# Altium schematic sheet Sheet1.SchDoc
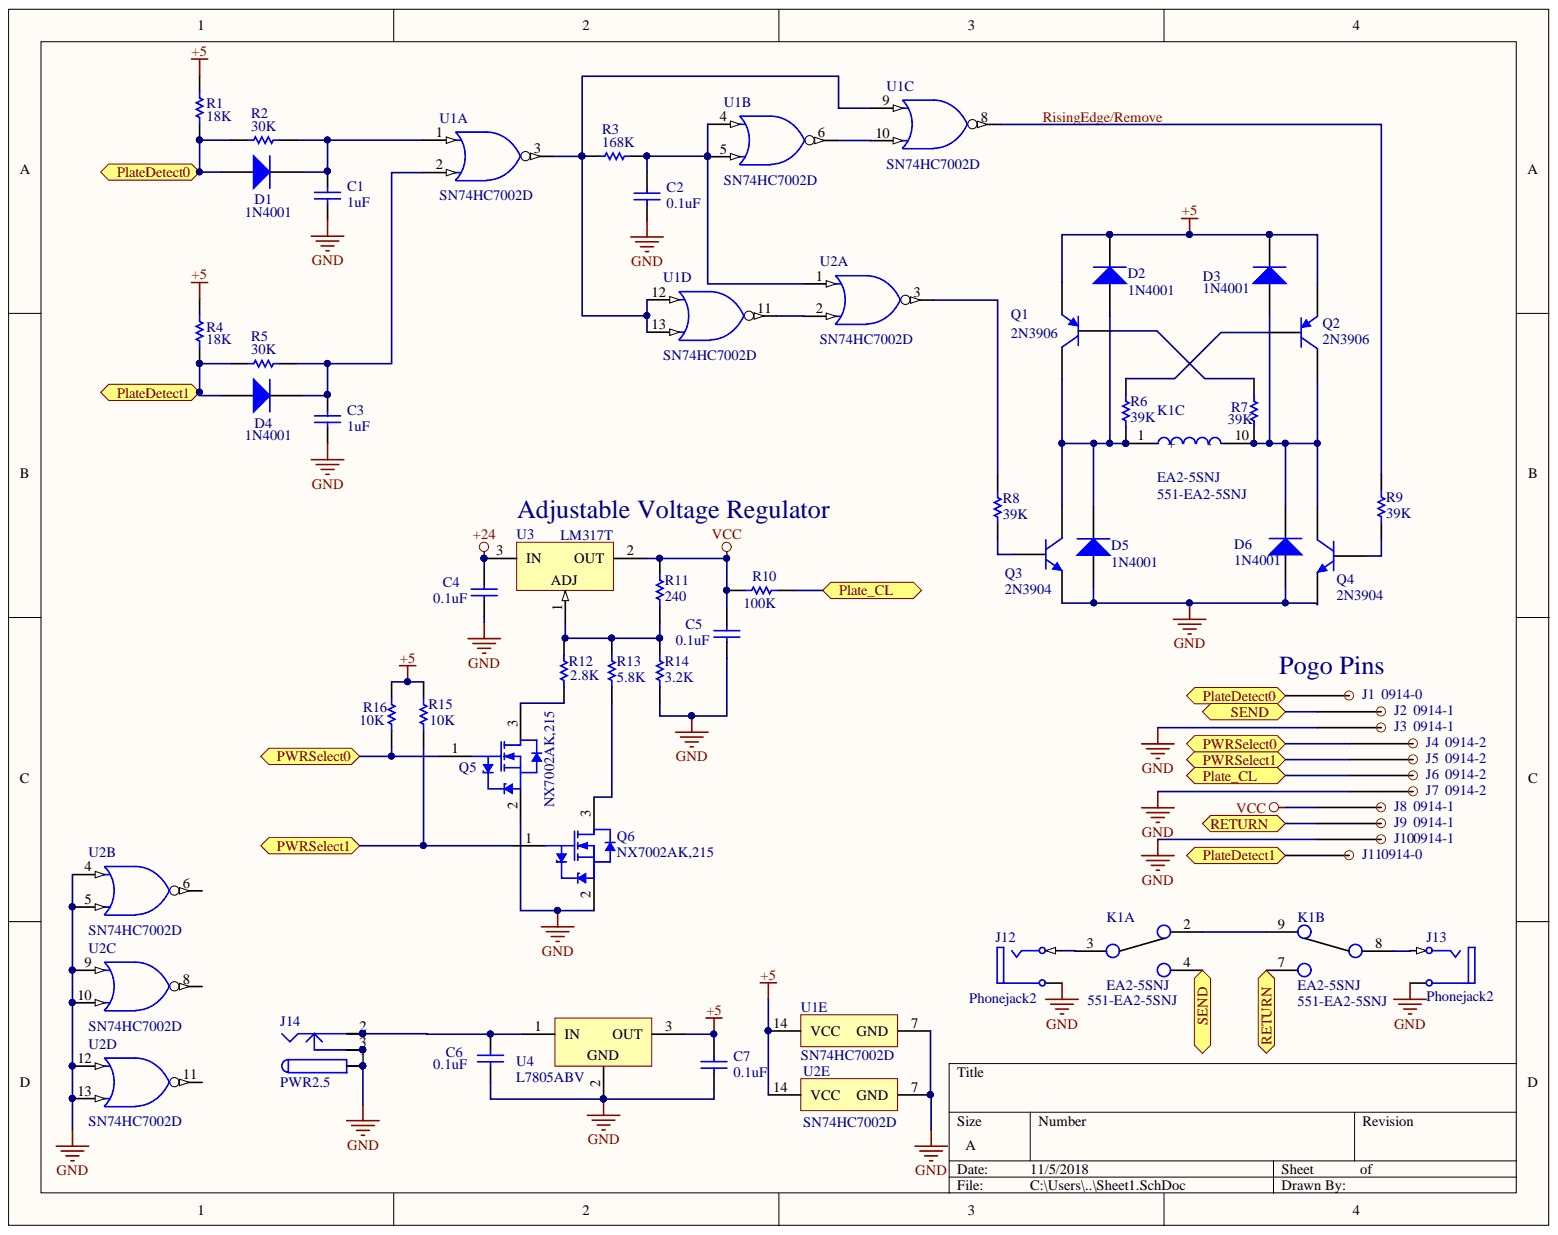
[diagram p0: Sheet1.SchDoc]

## Parts
C1  value 1uF  [RAD-0.3]  (hidden comment: Cap)
C2  value 0.1uF  [RAD-0.3]  (hidden comment: Cap)
C3  value 1uF  [RAD-0.3]  (hidden comment: Cap)
C4  value 0.1uF  [RAD-0.3]  (hidden comment: Cap)
C5  value 0.1uF  [RAD-0.3]  (hidden comment: Cap)
C6  value 0.1uF  [RAD-0.3]  (hidden comment: Cap)
C7  value 0.1uF  [RAD-0.3]  (hidden comment: Cap)
D1  1N4001  [SMC]
D2  1N4001  [SMC]
D3  1N4001  [SMC]
D4  1N4001  [SMC]
D5  1N4001  [SMC]
D6  1N4001  [SMC]
J1  0914-0-15-20-77-14-11-0  [MillMax0_025]  (value not drawn)
J2  0914-1-15-20-77-14-11-0  [MillMax0_025]  (value not drawn)
J3  0914-1-15-20-77-14-11-0  [MillMax0_025]  (value not drawn)
J4  0914-2-15-20-77-14-11-0  [MillMax0_025]  (value not drawn)
J5  0914-2-15-20-77-14-11-0  [MillMax0_025]  (value not drawn)
J6  0914-2-15-20-77-14-11-0  [MillMax0_025]  (value not drawn)
J7  0914-2-15-20-77-14-11-0  [MillMax0_025]  (value not drawn)
J8  0914-1-15-20-77-14-11-0  [MillMax0_025]  (value not drawn)
J9  0914-1-15-20-77-14-11-0  [MillMax0_025]  (value not drawn)
J10  0914-1-15-20-77-14-11-0  [MillMax0_025]  (value not drawn)
J11  0914-0-15-20-77-14-11-0  [MillMax0_025]  (value not drawn)
J12  Phonejack2  [JACK/6-V2]
J13  Phonejack2  [JACK/6-V2]
J14  PWR2.5  [KLD-0202]
K1  EA2-5SNJ  Supplier Part Number 1: 551-EA2-5SNJ  (parts A,B,C)
Q1  2N3906  [TO-92A]
Q2  2N3906  [TO-92A]
Q3  2N3904  [TO-92A]
Q4  2N3904  [TO-92A]
Q5  NX7002AK,215  [NXP-SOT23_N]
Q6  NX7002AK,215  [NXP-SOT23_N]
R1  value 18K  [AXIAL-0.3]  (hidden comment: Res1)
R2  value 30K  [AXIAL-0.3]  (hidden comment: Res1)
R3  value 168K  [AXIAL-0.3]  (hidden comment: Res1)
R4  value 18K  [AXIAL-0.3]  (hidden comment: Res1)
R5  value 30K  [AXIAL-0.3]  (hidden comment: Res1)
R6  value 39K  [AXIAL-0.3]  (hidden comment: Res1)
R7  value 39K  [AXIAL-0.3]  (hidden comment: Res1)
R8  value 39K  [AXIAL-0.3]  (hidden comment: Res1)
R9  value 39K  [AXIAL-0.3]  (hidden comment: Res1)
R10  value 100K  [AXIAL-0.3]  (hidden comment: Res1)
R11  value 240  [AXIAL-0.3]  (hidden comment: Res1)
R12  value 2.8K  [AXIAL-0.3]  (hidden comment: Res1)
R13  value 5.8K  [AXIAL-0.3]  (hidden comment: Res1)
R14  value 3.2K  [AXIAL-0.3]  (hidden comment: Res1)
R15  value 10K  [AXIAL-0.3]  (hidden comment: Res1)
R16  value 10K  [AXIAL-0.3]  (hidden comment: Res1)
U1  SN74HC7002D  [D0014A_N]  (parts A,B,C,D,E)
U2  SN74HC7002D  [D0014A_N]  (parts A,B,C,D,E)
U3  LM317T  [TO-220]
U4  L7805ABV  [TO220]

## Ports
Plate_CL, PlateDetect0, PlateDetect1, PWRSelect0, PWRSelect1, RETURN, SEND

## Nets
+5: C7.2 D2.2 D3.2 Q1.1 Q2.1 R1.2 R4.2 R15.2 R16.2 U1.14(VCC) U2.14(VCC) U4.3
+24: C4.2 U3.3
GND: C1.1 C2.1 C3.1 C4.1 C5.1 C6.1 C7.1 D5.1 D6.1 J3.1 J7.1 J10.1 J12.5 J13.5 J14.1 J14.1A J14.1B Q3.1 Q4.1 Q5.2 Q6.2 R14.1 U1.7(GND) U2.4 U2.5 U2.7(GND) U2.9 U2.10 U2.12 U2.13 U4.2
VCC: C5.2 J8.1 R10.2 R11.2 U3.2
Plate_CL: J6.1 R10.1 ; port Plate_CL
PlateDetect0: D1.1 J1.1 R1.1 R2.1 ; port PlateDetect0
PlateDetect1: D4.1 J11.1 R4.1 R5.1 ; port PlateDetect1
PWRSelect0: J4.1 Q5.1 R16.1 ; port PWRSelect0
PWRSelect1: J5.1 Q6.1 R15.1 ; port PWRSelect1
RETURN: J9.1 K1.7 ; port RETURN
RisingEdge/Remove: R9.1 U1.8
SEND: J2.1 K1.4 ; port SEND
NetC1_2: C1.2 D1.2 R2.2 U1.1
NetC2_2: C2.2 R3.2 U1.4 U1.5 U2.1
NetC3_2: C3.2 D4.2 R5.2 U1.2
NetC6_2: C6.2 J14.2 J14.2A J14.2B U4.1
NetD2_1: D2.1 D5.2 K1.1(+) Q1.3 Q3.3 R6.1
NetD3_1: D3.1 D6.2 K1.10(-) Q2.3 Q4.3 R7.1
NetJ12_1: J12.1 K1.3
NetJ13_1: J13.1 K1.8
NetJ14_3: J14.3 J14.3A J14.3B
NetK1_2: K1.2 K1.9
NetQ1_2: Q1.2 R7.2
NetQ2_2: Q2.2 R6.2
NetQ3_2: Q3.2 R8.2
NetQ4_2: Q4.2 R9.2
NetQ5_3: Q5.3 R12.1
NetQ6_3: Q6.3 R13.1
NetR3_1: R3.1 U1.3 U1.9 U1.12 U1.13
NetR8_1: R8.1 U2.3
NetR11_1: R11.1 R12.2 R13.2 R14.2 U3.1
NetU1_6: U1.6 U1.10
NetU1_11: U1.11 U2.2

Not connected: U2 6 8 11

## Library data of the parts
C1-C7 = Cap: Capacitor; Component Kind: Standard; LatestRevisionDate: 17-Jul-2002; LatestRevisionNote: Re-released for DXP Platform.; Library Name: Miscellaneous Devices.IntLib; PackageDescription: Radial Cap, Thru-Hole; 2 Leads; 0.3 in Pin Spacing; PackageReference: RAD-0.3; Published: 8-Jun-2000; Signal Integrity: Cap; Simulation: CAP; Publisher: Altium Limited
D1-D6 = Diode: Default Diode; Code_JEDEC: DO-214-AB; Component Kind: Standard; LatestRevisionDate: 17-Jul-2002; LatestRevisionNote: Re-released for DXP Platform.; Library Name: Miscellaneous Devices.IntLib; PackageDescription: DO-214-AB/SMC; 2 C-Bend Leads; Body 7.9 x 5.9 mm, inc. leads (LxW); PackageReference: SMC; PackageVersion: Sep-1996; Published: 8-Jun-2000; Signal Integrity: Diode; Simulation: DIODE; Publisher: Altium Limited
J1 J11 = 0914-0-15-20-77-14-11-0: CONN PIN SPRING-LOADED PCB GOLD; Manufacturer: Mill-Max; Body Material: Brass; Current Rating: 2A; Number of Contacts: 2; P*: 0914-0; Resistance: 20mR; Component Kind: Standard; ComponentLink1Description: http://www.mouser.com/ds/2/273/025-259718.pdf; ComponentLink1URL: http://www.mouser.com/ds/2/273/025-259718.pdf; ComponentLink2Description: http://www.farnell.com/datasheets/1474874.pdf; ComponentLink2URL: http://www.farnell.com/datasheets/1474874.pdf; ComponentLink3Description: https://www.alliedelec.com/m/d/29d0a7827c1825043739d39c5225e64e.pdf; ComponentLink3URL: https://www.alliedelec.com/m/d/29d0a7827c1825043739d39c5225e64e.pdf; Contact Material: Copper Alloy; Contact Plating: Gold; Lead Free: Lead Free; Length: 0.302inch; Manufacturer Part Number: 0914-0-15-20-77-14-11-0; Material: Copper Alloy; Mount: Panel,Through Hole; Number of Rows: 1; Package Quantity: 500; Packaging: Bulk; Plating: Gold; Pricing 1: 1=0.75, 10=0.706, 25=0.6352, 50=0.5648, 100=0.5412, 250=0.49412, 500=0.4706, 1000=0.38825, 2500=0.35295 (USD); REACH SVHC: Unknown; Stock 1: 29402; Supplier 1: Digi-Key; Termination: Solder; Library Name: NickPhamParts.IntLib
J2 J3 J8-J10 = 0914-1-15-20-77-14-11-0: CONNECTOR; Manufacturer: Mill-Max; J*: 0914-1; Plating: Gold; Component Kind: Standard; Manufacturer Part Number: 0914-1-15-20-77-14-11-0; Material: Brass; Mount: Through Hole; Pricing 1: 1=0.77, 10=0.673, 25=0.6336, 50=0.6072, 100=0.5808, 250=0.528, 500=0.4752, 1000=0.4224, 2500=0.3828 (USD); Stock 1: 17746; Supplier 1: Digi-Key; Library Name: NickPhamParts.IntLib
J4-J7 = 0914-2-15-20-77-14-11-0: CONN PIN PCB .362"; Manufacturer: Mill-Max; Plating: Gold; Component Kind: Standard; Manufacturer Part Number: 0914-2-15-20-77-14-11-0; Material: Brass; Mount: Through Hole; Pricing 1: 1=0.81, 10=0.71, 25=0.6688, 50=0.6408, 100=0.6129, 250=0.5572, 500=0.50148, 1000=0.44576, 2500=0.40397 (USD); Stock 1: 7703; Supplier 1: Digi-Key; Supplier Part Number 1: 0914-2; Library Name: NickPhamParts.IntLib
J12 J13 = Phonejack2: Jack Socket, 1/4" [6.5mm], Thru-Hole, Vertical, 2-Conductor Open Circuit; DrillAndSlotSize: Corresponds to nominal value.; PackageDocument: 2003; PCB_Layout: Complies with manufacturer's recommendation.; Component Kind: Standard; ComponentLink1Description: Manufacturer Link; ComponentLink1URL: http://www.cliffuk.co.uk/; DatasheetDocument: 2003; LatestRevisionDate: 15-Jul-2004; LatestRevisionNote: Component Replaced.; Library Name: Miscellaneous Connectors.IntLib; PackageReference: S1VS_SNS; Signal Integrity: JACK Socket; Publisher: Altium Limited
J14 = PWR2.5: Low Voltage Power Supply Connector; Component Kind: Standard; ComponentLink1Description: Manufacturer Link; ComponentLink1URL: http://domino.kycon.com/internet/Products.html; DatasheetDocument: 09-May-2002; Library Name: Miscellaneous Connectors.IntLib; Published: 17-Dec-2003; Signal Integrity: Connector; Publisher: Altium Limited
K1 = EA2-5SNJ: Low Signal Relays - PCB 5VDC SINGLE LATCH; Manufacturer: NEC; Coil Current: 2A; Coil Type: Latching; Power Consumption: 100mW; Throw Configuration: DPDT; Component Kind: Standard; Manufacturer Part Number: EA2-5SNJ; Package Quantity: 40; Stock 1: 0; Supplier 1: Mouser; Termination: Solder; Library Name: NickPhamParts.IntLib
Q1 Q2 = 2N3906: PNP General Purpose Amplifier; Component Kind: Standard; ComponentLink1Description: Manufacturer Link; ComponentLink1URL: http://www.fairchildsemi.com/; ComponentLink2Description: Datasheet; ComponentLink2URL: http://www.fairchildsemi.com/ds/2N%2F2N3906.pdf; DatasheetVersion: 1997; LatestRevisionDate: 15-Jan-2003; LatestRevisionNote: PCB Footprint 'BCY-W3/D4.7' replaced; Library Name: Miscellaneous Devices.IntLib; PackageDescription: TO, Flat Index; 3 In-Line, Axial Leads; Body Dia. 4.5mm; Leads 0.51 x 0.48 mm (max); PackageReference: TO-92A; PackageVersion: Sep-1998; Published: 8-Jun-2000; Signal Integrity: 2N3906; Simulation: 2N3906; Publisher: Altium Limited
Q3 Q4 = 2N3904: NPN General Purpose Amplifier; Component Kind: Standard; ComponentLink1Description: Manufacturer Link; ComponentLink1URL: http://www.fairchildsemi.com/; ComponentLink2Description: Datasheet; ComponentLink2URL: http://www.fairchildsemi.com/ds/2N%2F2N3904.pdf; DatasheetVersion: 1997; LatestRevisionDate: 15-Jan-2003; LatestRevisionNote: PCB Footprint 'BCY-W3/D4.7' replaced; Library Name: Miscellaneous Devices.IntLib; PackageDescription: TO, Flat Index; 3 In-Line, Axial Leads; Body Dia. 4.5mm; Leads 0.51 x 0.48 mm (max); PackageReference: TO-92A; PackageVersion: Sep-1998; Published: 8-Jun-2000; Signal Integrity: 2N3904; Simulation: 2N3904; Publisher: Altium Limited
Q5 Q6 = NX7002AK,215: Single N-Channel Trench MOSFET, 60 V, -55 to 150 degC, 3-Pin SOT23, Tape and Reel; Manufacturer: NXP Semiconductors; Channel Type: N; Ciss [typ] (pF): 11; Coss [typ] (pF): 3.4; Packing: Tape & Reel; VDS [max] (V): 60; VGSth [typ] (V): 1.6; Code_JEDEC: TO-236AB; Component Kind: Standard; ComponentLink1Description: Manufacturer URL; ComponentLink1URL: http://www.nxp.com/; ComponentLink2Description: Datasheet; ComponentLink2URL: http://www.nxp.com/documents/data_sheet/NX7002AK.pdf; ComponentLink3Description: Package Specification; ComponentLink3URL: http://www.nxp.com/documents/outline_drawing/sot023_po.pdf; DatasheetVersion: Rev. 5; Library Name: NXP Semiconductors Standard MOSFETs.IntLib; Mounting Technology: Surface Mount; PackageDescription: 3-Pin Small Outline Transistor Package, Body 2.9 x 1.3 mm, Pitch 0.95 mm; PackageReference: SOT23; PackageVersion: 06-03-16, 03/2006; PartNumber: NX7002AK,215; Supplier 1: Digi-Key; Supplier 2: Digi-Key; Supplier 3: Digi-Key; Supplier 4: Mouser; Supplier Part Number 1: 568-10510-2-ND; Supplier Part Number 2: 568-10510-1-ND; Supplier Part Number 3: 568-10510-6-ND; Supplier Part Number 4: 771-NX7002AK,215
R1-R16 = Res1: Resistor; Component Kind: Standard; LatestRevisionDate: 17-Jul-2002; LatestRevisionNote: Re-released for DXP Platform.; Library Name: Miscellaneous Devices.IntLib; PackageDescription: Axial Device, Thru-Hole; 2 Leads; 0.3 in Pin Spacing; PackageReference: AXIAL-0.3; Published: 8-Jun-2000; Signal Integrity: Res; Simulation: RESISTOR; Publisher: Altium Limited
U1 U2 = SN74HC7002D: Quadruple Positive-NOR Gates With Schmitt-Trigger Inputs, D0014A, TUBE; Manufacturer: Texas Instruments; Bits(#): 4; F @ Nom Voltage(Max)(Mhz): 70; ICC @ Nom Voltage(Max)(mA): 0.02; Input Type: CMOS; Output Drive (IOL/IOH)(Max)(mA): 4/-4; Rating: Catalog; tpd @ Nom Voltage(Max)(ns): 33; VCC(Max)(V): 6; VCC(Min)(V): 2; Voltage(Nom)(V): 5; Code_JEDEC: MS-012; Component Kind: Standard; ComponentLink1Description: Manufacturer URL; ComponentLink1URL: http://www.ti.com/; ComponentLink2Description: Datasheet; ComponentLink2URL: http://www.ti.com/lit/ds/symlink/sn74hc7002.pdf; ComponentLink3Description: Package Specification; ComponentLink3URL: http://www.ti.com/lit/ml/mpds177g/mpds177g.pdf; DatasheetVersion: SCLS033F; Generic Part Number: SN74HC7002; Library Name: TI_Logic_Gate.IntLib; Operating Temperature Range(C): -40 to 85; Output Type: CMOS; PackageReference: D0014A; PartNumber: SN74HC7002D
U3 = LM317T: 1.5A, 1.2V to 37V Adjustable Voltage Regulator, 3-Pin TO-220; Component Kind: Standard; ComponentLink1Description: Manufacturer URL; ComponentLink1URL: http://www.st.com; ComponentLink2Description: Datasheet; ComponentLink2URL: http://www.st.com/internet/com/TECHNICAL_RESOURCES/TECHNICAL_LITERATURE/DATASHEET/CD00000455.pdf; ComponentLink3Description: Package Specification; ComponentLink3URL: http://www.st.com/internet/com/TECHNICAL_RESOURCES/TECHNICAL_LITERATURE/PACKAGE_INFORMATION/CD00004649.pdf; DatasheetVersion: Nov-2011; Library Name: STMicroelectronics Power Management Linear Regulator.IntLib; PackageDescription: TO, Thru-Hole, Vertical, Heatsink Mounted; 3 In-Line Leads; Pitch 2.54 mm; PackageReference: TO-220; PackageVersion: 2011; PartNumber: LM317T
U4 = L7805ABV: Positive Voltage Regulator, 5V, 3-Pin TO-220; Component Kind: Standard; ComponentLink1Description: Manufacturer URL; ComponentLink1URL: http://www.st.com; ComponentLink2Description: Datasheet; ComponentLink2URL: http://www.st.com/internet/com/TECHNICAL_RESOURCES/TECHNICAL_LITERATURE/DATASHEET/CD00000444.pdf; ComponentLink3Description: Package Specification; ComponentLink3URL: http://www.st.com/internet/com/TECHNICAL_RESOURCES/TECHNICAL_LITERATURE/PACKAGE_INFORMATION/CD00004649.pdf; DatasheetVersion: Nov-2011; Library Name: STMicroelectronics Power Management Linear Regulator.IntLib; PackageDescription: TO, Thru-Hole, Vertical, Heatsink Mounted; 3 In-Line Leads; Pitch 2.54 mm; PackageReference: TO220; PackageVersion: ver3.0; PartNumber: L7805ABV
